# Altium schematic sheet hellen1-mega-mcu144.SchDoc(hellen1-mega-mcu144)
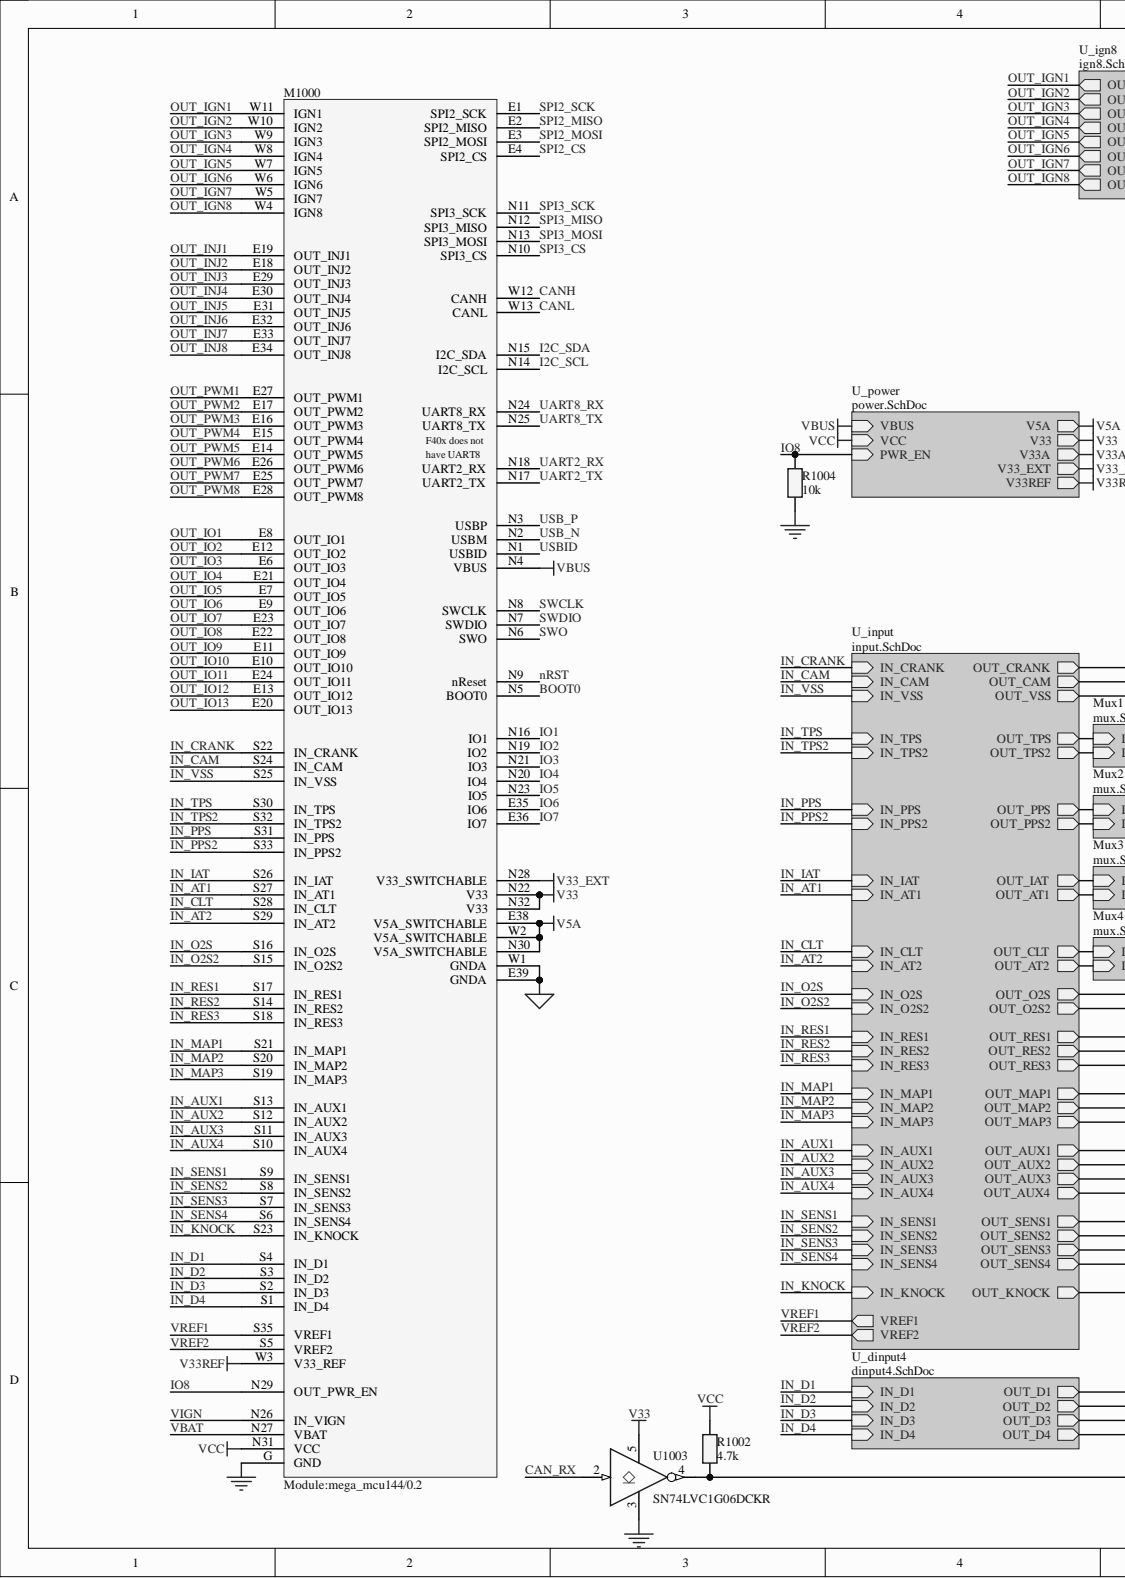
[diagram p0: hellen1-mega-mcu144.SchDoc, left]
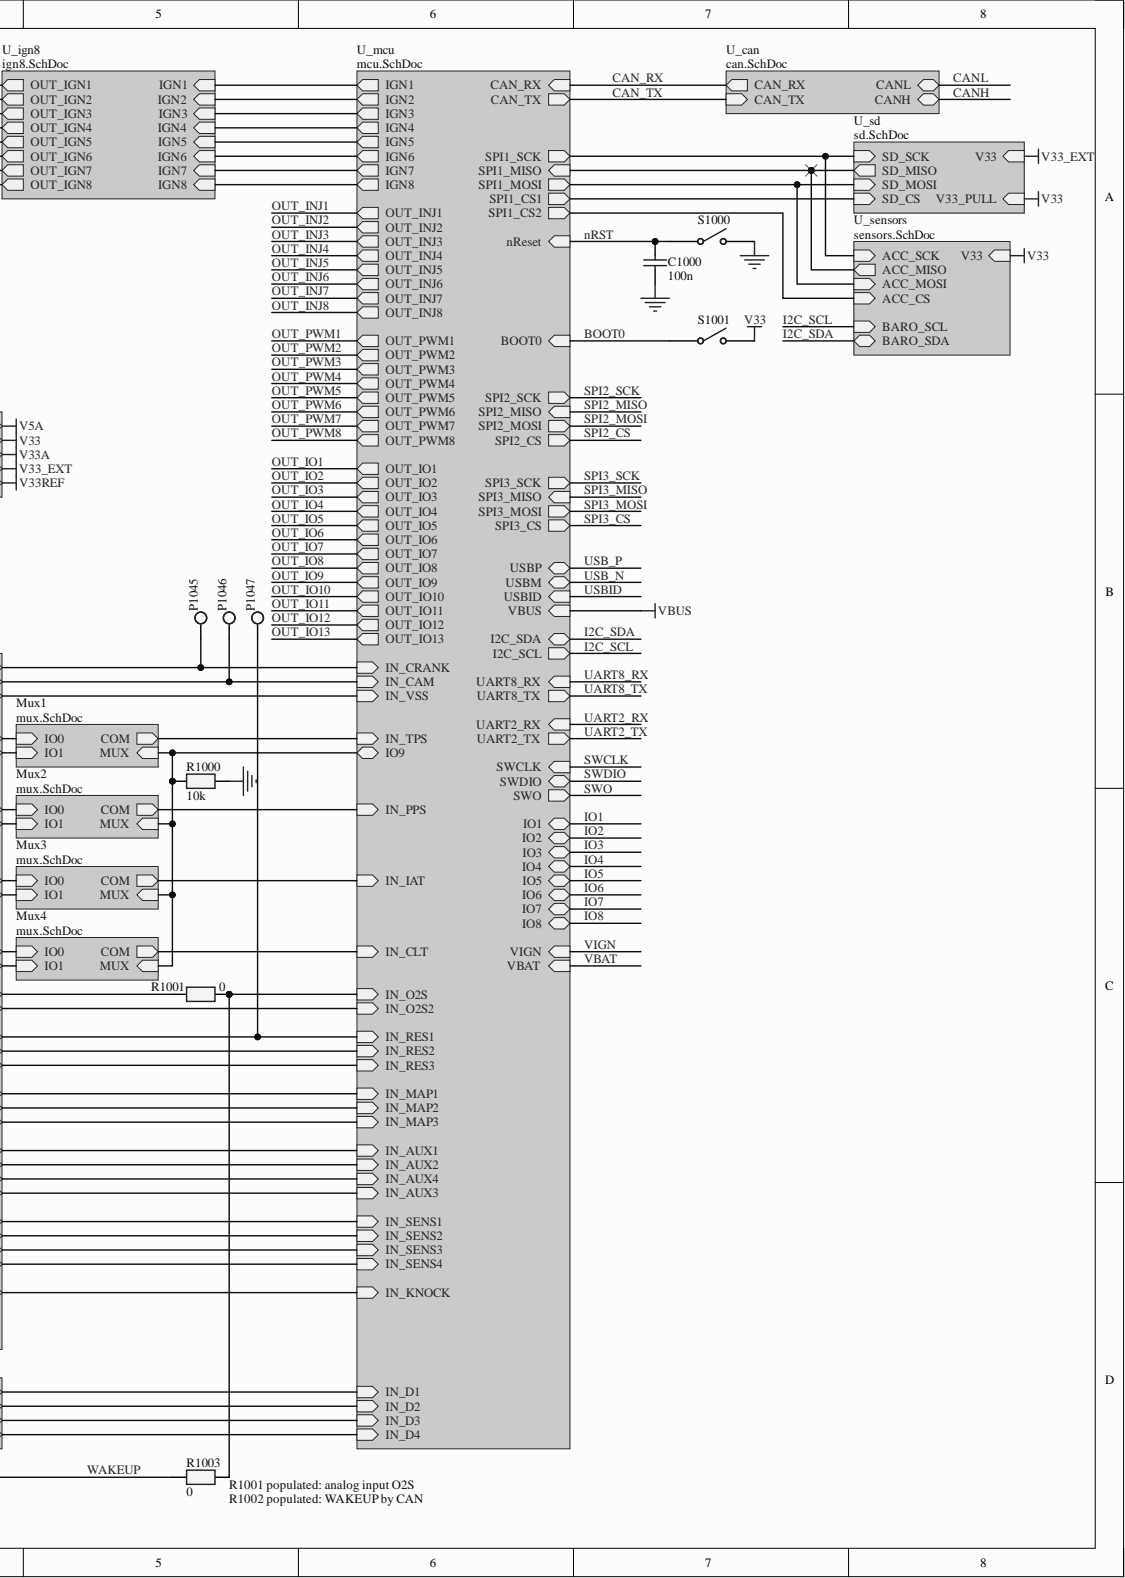
[diagram p1: hellen1-mega-mcu144.SchDoc, right]

## Parts
C1000  100n  [C0402HD]
M1000  Module:mega_mcu144/0.2  [MOD_Hellen_MEGA_MCU144_0.2]
P1045  CRK  [HDR1X1]  (value not drawn)  (no BOM)
P1046  CAM  [HDR1X1]  (value not drawn)  (no BOM)
P1047  RES1  [HDR1X1]  (value not drawn)  (no BOM)
R1000  10k  [R0402]
R1001  0  [R0402]
R1002  4.7k  [R0402]
R1003  0  [R0402]
R1004  10k  [R0402]
S1000  BTN  [BUTTON-SKRK]  (value not drawn)
S1001  BTN  [BUTTON-SKRK]  (value not drawn)
U1003  SN74LVC1G06DCKR  [SOT-353]

## Nets
GND: C1000.1 M1000.G(GND) R1000.2 R1004.1 S1000.2 U1003.3
GNDA: M1000.E39(GNDA) M1000.W1(GNDA)
V5A: M1000.E38(V5A_SWITCHABLE) M1000.N30(V5A_SWITCHABLE) M1000.W2(V5A_SWITCHABLE)
V33: M1000.N22(V33) M1000.N32(V33) S1001.2 U1003.5
V33_EXT: M1000.N28(V33_SWITCHABLE)
V33REF: M1000.W3(V33_REF)
VBUS: M1000.N4(VBUS)
VCC: M1000.N31(VCC) R1002.1
BOOT0: M1000.N5(BOOT0) S1001.1
CAN_RX: U1003.2
CANH: M1000.W12(CANH)
CANL: M1000.W13(CANL)
I2C_SCL: M1000.N14(I2C_SCL)
I2C_SDA: M1000.N15(I2C_SDA)
IN_AT1: M1000.S27(IN_AT1)
IN_AT2: M1000.S29(IN_AT2)
IN_AUX1: M1000.S13(IN_AUX1)
IN_AUX2: M1000.S12(IN_AUX2)
IN_AUX3: M1000.S11(IN_AUX3)
IN_AUX4: M1000.S10(IN_AUX4)
IN_CAM: M1000.S24(IN_CAM)
IN_CLT: M1000.S28(IN_CLT)
IN_CRANK: M1000.S22(IN_CRANK)
IN_D1: M1000.S4(IN_D1)
IN_D2: M1000.S3(IN_D2)
IN_D3: M1000.S2(IN_D3)
IN_D4: M1000.S1(IN_D4)
IN_IAT: M1000.S26(IN_IAT)
IN_KNOCK: M1000.S23(IN_KNOCK)
IN_MAP1: M1000.S21(IN_MAP1)
IN_MAP2: M1000.S20(IN_MAP2)
IN_MAP3: M1000.S19(IN_MAP3)
IN_O2S: M1000.S16(IN_O2S)
IN_O2S2: M1000.S15(IN_O2S2)
IN_PPS: M1000.S31(IN_PPS)
IN_PPS2: M1000.S33(IN_PPS2)
IN_RES1: M1000.S17(IN_RES1)
IN_RES2: M1000.S14(IN_RES2)
IN_RES3: M1000.S18(IN_RES3)
IN_SENS1: M1000.S9(IN_SENS1)
IN_SENS2: M1000.S8(IN_SENS2)
IN_SENS3: M1000.S7(IN_SENS3)
IN_SENS4: M1000.S6(IN_SENS4)
IN_TPS: M1000.S30(IN_TPS)
IN_TPS2: M1000.S32(IN_TPS2)
IN_VSS: M1000.S25(IN_VSS)
IO1: M1000.N16(IO1)
IO2: M1000.N19(IO2)
IO3: M1000.N21(IO3)
IO4: M1000.N20(IO4)
IO5: M1000.N23(IO5)
IO6: M1000.E35(IO6)
IO7: M1000.E36(IO7)
IO8: M1000.N29(OUT_PWR_EN) R1004.2
nRST: C1000.2 M1000.N9(nReset) S1000.1
OUT_IGN1: M1000.W11(IGN1)
OUT_IGN2: M1000.W10(IGN2)
OUT_IGN3: M1000.W9(IGN3)
OUT_IGN4: M1000.W8(IGN4)
OUT_IGN5: M1000.W7(IGN5)
OUT_IGN6: M1000.W6(IGN6)
OUT_IGN7: M1000.W5(IGN7)
OUT_IGN8: M1000.W4(IGN8)
OUT_INJ1: M1000.E19(OUT_INJ1)
OUT_INJ2: M1000.E18(OUT_INJ2)
OUT_INJ3: M1000.E29(OUT_INJ3)
OUT_INJ4: M1000.E30(OUT_INJ4)
OUT_INJ5: M1000.E31(OUT_INJ5)
OUT_INJ6: M1000.E32(OUT_INJ6)
OUT_INJ7: M1000.E33(OUT_INJ7)
OUT_INJ8: M1000.E34(OUT_INJ8)
OUT_IO1: M1000.E8(OUT_IO1)
OUT_IO2: M1000.E12(OUT_IO2)
OUT_IO3: M1000.E6(OUT_IO3)
OUT_IO4: M1000.E21(OUT_IO4)
OUT_IO5: M1000.E7(OUT_IO5)
OUT_IO6: M1000.E9(OUT_IO6)
OUT_IO7: M1000.E23(OUT_IO7)
OUT_IO8: M1000.E22(OUT_IO8)
OUT_IO9: M1000.E11(OUT_IO9)
OUT_IO10: M1000.E10(OUT_IO10)
OUT_IO11: M1000.E24(OUT_IO11)
OUT_IO12: M1000.E13(OUT_IO12)
OUT_IO13: M1000.E20(OUT_IO13)
OUT_PWM1: M1000.E27(OUT_PWM1)
OUT_PWM2: M1000.E17(OUT_PWM2)
OUT_PWM3: M1000.E16(OUT_PWM3)
OUT_PWM4: M1000.E15(OUT_PWM4)
OUT_PWM5: M1000.E14(OUT_PWM5)
OUT_PWM6: M1000.E26(OUT_PWM6)
OUT_PWM7: M1000.E25(OUT_PWM7)
OUT_PWM8: M1000.E28(OUT_PWM8)
SPI2_CS: M1000.E4(SPI2_CS)
SPI2_MISO: M1000.E2(SPI2_MISO)
SPI2_MOSI: M1000.E3(SPI2_MOSI)
SPI2_SCK: M1000.E1(SPI2_SCK)
SPI3_CS: M1000.N10(SPI3_CS)
SPI3_MISO: M1000.N12(SPI3_MISO)
SPI3_MOSI: M1000.N13(SPI3_MOSI)
SPI3_SCK: M1000.N11(SPI3_SCK)
SWCLK: M1000.N8(SWCLK)
SWDIO: M1000.N7(SWDIO)
SWO: M1000.N6(SWO)
UART2_RX: M1000.N18(UART2_RX)
UART2_TX: M1000.N17(UART2_TX)
UART8_RX: M1000.N24(UART8_RX)
UART8_TX: M1000.N25(UART8_TX)
USB_N: M1000.N2(USBM)
USB_P: M1000.N3(USBP)
USBID: M1000.N1(USBID)
VBAT: M1000.N27(VBAT)
VIGN: M1000.N26(IN_VIGN)
VREF1: M1000.S35(VREF1)
VREF2: M1000.S5(VREF2)
WAKEUP: R1002.2 R1003.2 U1003.4
NetP1045_1: P1045.1
NetP1046_1: P1046.1
NetP1047_1: P1047.1
NetR1000_1: R1000.1
NetR1001_1: R1001.1 R1003.1
NetR1001_2: R1001.2
ACC_CS:
ACC_MISO:
ACC_MOSI:
ACC_SCK:
CAN_TX:
COM:
IGN1:
IGN2:
IGN3:
IGN4:
IGN5:
IGN6:
IGN7:
IGN8:
IO0:
SD_CS:
V33A:

## Library data of the parts
C1000 = Cap: Capacitor; Fitted: True; Type: SMD; Component Kind: Standard; Library Name: General.schlib; PackageReference: C0402; Signal Integrity: Capacitor; Supplier 3: LCSC; Supplier Part Number 3: C1525
M1000 = Mod-Hellen-MEGA_MCU144_0.2: Hellen One MEGA_MCU144 Module; Fitted: False; Component Kind: Standard; Library Name: Mod.SchLib; Supplier 1: Mouser; Supplier 2: Digi-Key; Supplier 3: LCSC; Type: Module; Publisher: qwerty-off
P1045-P1047 = Pad: Fitted: False; Description: Pad; Library Name: General.schlib
R1000-R1004 = Res: Resistor; Fitted: True; Component Kind: Standard; Library Name: General.schlib; PackageReference: R0402; Signal Integrity: Resistor; Supplier 3: LCSC; Type: SMD
  R1000: PCB3D: 0805; Supplier Part Number 3: C11616
  R1001 R1003: PCB3D: 0805; Supplier Part Number 3: C17168
  R1002: PCB3D: 0402; Supplier 1: Mouser; Supplier 2: DigiKey; Supplier Part Number 3: C25900
  R1004: PCB3D: 0402; Supplier Part Number 3: C11616
S1000 S1001 = Switch SPST: Single-Pole, Single-Throw Switch; Fitted: True; Component Kind: Standard; LatestRevisionDate: 17-Jul-2002; LatestRevisionNote: Re-released for DXP Platform.; Library Name: General.schlib; PackageReference: SMD-2_2.9x3.9x1.7; Published: 24-Mar-1999; Supplier 3: LCSC; Supplier Part Number 3: C115357; Type: SMD; Publisher: Altium Limited
U1003 = 74LVC1G06: Component Kind: Standard; Library Name: IC.SchLib; PackageReference: SOT-353; Supplier 1: Mouser; Supplier 2: DigiKey; Supplier 3: LCSC; Supplier Part Number 3: C7828; Type: SMD
